# Altium schematic sheet Power&CH340G.SchDoc
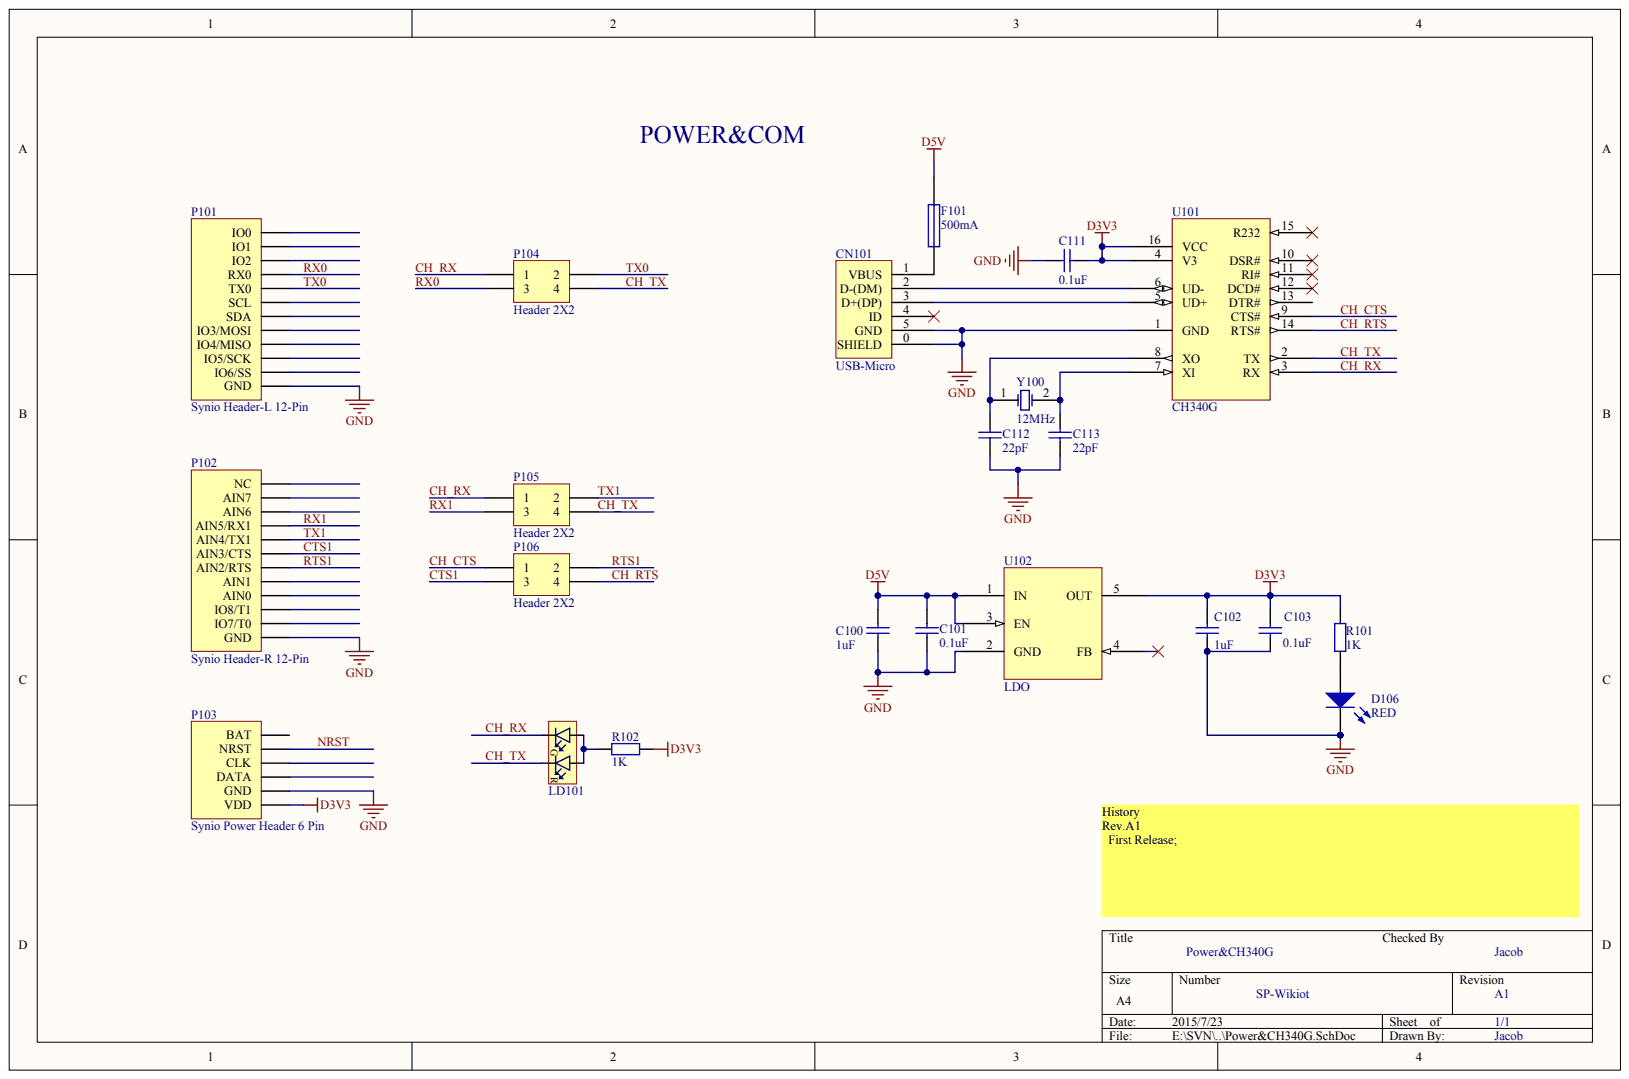
[diagram p0: Power&CH340G.SchDoc]

## Parts
C100  1uF
C101  0.1uF
C102  1uF
C103  0.1uF
C111  0.1uF
C112  22pF
C113  22pF
CN101  USB-Micro
D106  RED
F101  500mA
LD101  LTST-C195KGJRKT  [LED_DUAL_0606]  (value not drawn)
P101  Synio Header-L 12-Pin
P102  Synio Header-R 12-Pin
P103  Synio Power Header 6 Pin
P104  Header 2X2
P105  Header 2X2
P106  Header 2X2
R101  1K
R102  1K
U101  CH340G
U102  LDO
Y100  12MHz

## Nets
D3V3: C102.2 C103.2 C111.2 P103.1(VDD) R101.2 R102.1 U101.4(V3) U101.16(VCC) U102.5(OUT)
D5V: C100.2 C101.2 F101.1 U102.1(IN) U102.3(EN)
GND: C100.1 C101.1 C102.1 C103.1 C111.1 C112.1 C113.1 CN101.0(SHIELD) CN101.5(GND) D106.2 P101.1(GND) P102.1(GND) P103.2(GND) U101.1(GND) U102.2(GND)
CH_CTS: P106.1 U101.9(CTS#)
CH_RTS: P106.4 U101.14(RTS#)
CH_RX: LD101.1 P104.1 P105.1 U101.3(RX)
CH_TX: LD101.2 P104.4 P105.4 U101.2(TX)
CTS1: P102.7(AIN3/CTS) P106.3
NRST: P103.5(NRST)
RTS1: P102.6(AIN2/RTS) P106.2
RX0: P101.9(RX0) P104.3
RX1: P102.9(AIN5/RX1) P105.3
TX0: P101.8(TX0) P104.2
TX1: P102.8(AIN4/TX1) P105.2
NetC112_2: C112.2 U101.8(XO) Y100.1
NetC113_2: C113.2 U101.7(XI) Y100.2
NetCN101_1: CN101.1(VBUS) F101.2
NetCN101_2: CN101.2(D-(DM)) U101.6(UD-)
NetCN101_3: CN101.3(D+(DP)) U101.5(UD+)
NetD106_1: D106.1 R101.1
NetLD101_3: LD101.3 LD101.4 R102.2
NetU102_4: U102.4(FB)

Not connected: CN101 4(ID); P101 2(IO6/SS) 3(IO5/SCK) 4(IO4/MISO) 5(IO3/MOSI) 6(SDA) 7(SCL) 10(IO2) 11(IO1) 12(IO0); P102 2(IO7/T0) 3(IO8/T1) 4(AIN0) 5(AIN1) 10(AIN6) 11(AIN7) 12(NC); P103 3(DATA) 4(CLK) 6(BAT); U101 10(DSR#) 11(RI#) 12(DCD#) 13(DTR#) 15(R232)

## Library data of the parts
LD101 = (no symbol name): Manufacturer: Lite-On Inc; 2nd source part number: 859-LTST-C195KGJRKT; Note: HSMF-A203- xxxxx GaP Red GaP Emerald Green; Artikkelnr Noca: 13019145; Part Number: LTST-C195KGJRKT; Manufacturer Part Number: LTST-C195KGJRKT; Datasheet: http://media.digikey.com/pdf/Data%20Sheets/Lite-On%20PDFs/LTST-C195KGJRKT.pdf; LatestRevisionDate: 2012-07-05; Pin Count: 4; Published: 2012-07-05; Value: LTST-C195KGJRKT; Supplier: Digi-Key; Supplier part number: 160-1452-1-ND; 2nd source Supplier: Mouser; Publisher: Nordic Semiconductor
C100-C103 C111-C113 CN101 D106 F101 P101-P106 R101 R102 U101 U102 Y100 = (no symbol name)
  C100 C102: Published: 8-Jun-2000; LatestRevisionDate: 17-Jul-2002; LatestRevisionNote: Re-released for DXP Platform.; PackageReference: RAD-0.3; Value: 1uF
  C101 C103 C111: Published: 8-Jun-2000; LatestRevisionDate: 17-Jul-2002; LatestRevisionNote: Re-released for DXP Platform.; PackageReference: RAD-0.3; Value: 0.1uF
  C112 C113: Published: 8-Jun-2000; LatestRevisionDate: 17-Jul-2002; LatestRevisionNote: Re-released for DXP Platform.; PackageReference: RAD-0.3; Value: 22pF
  D106: Published: 8-Jun-2000; LatestRevisionDate: 08-Jul-2005; LatestRevisionNote: Mech Layer 1 removed.; PackageReference: LED-0
  F101: Published: 8-Jun-2000; LatestRevisionDate: 17-Jul-2002; LatestRevisionNote: Re-released for DXP Platform.; PackageReference: PIN-W2/E2.8; PackageDescription: Thru-Hole; 2 Leads
  P101 P102 P104-P106: LatestRevisionDate: 17-Jul-2002; LatestRevisionNote: Re-released for DXP Platform.
  R101 R102: Published: 8-Jun-2000; LatestRevisionDate: 17-Jul-2002; LatestRevisionNote: Re-released for DXP Platform.; PackageReference: AXIAL-0.4; Value: 1K
  Y100: Published: 8-Jun-2000; LatestRevisionDate: 17-Jul-2002; LatestRevisionNote: Re-released for DXP Platform.; PackageReference: R38
  C112 C113 D106 F101 P101 P102 P104-P106 R101 R102 Y100: Publisher: Altium Limited
